# Altium schematic sheet KeyBoard.SchDoc
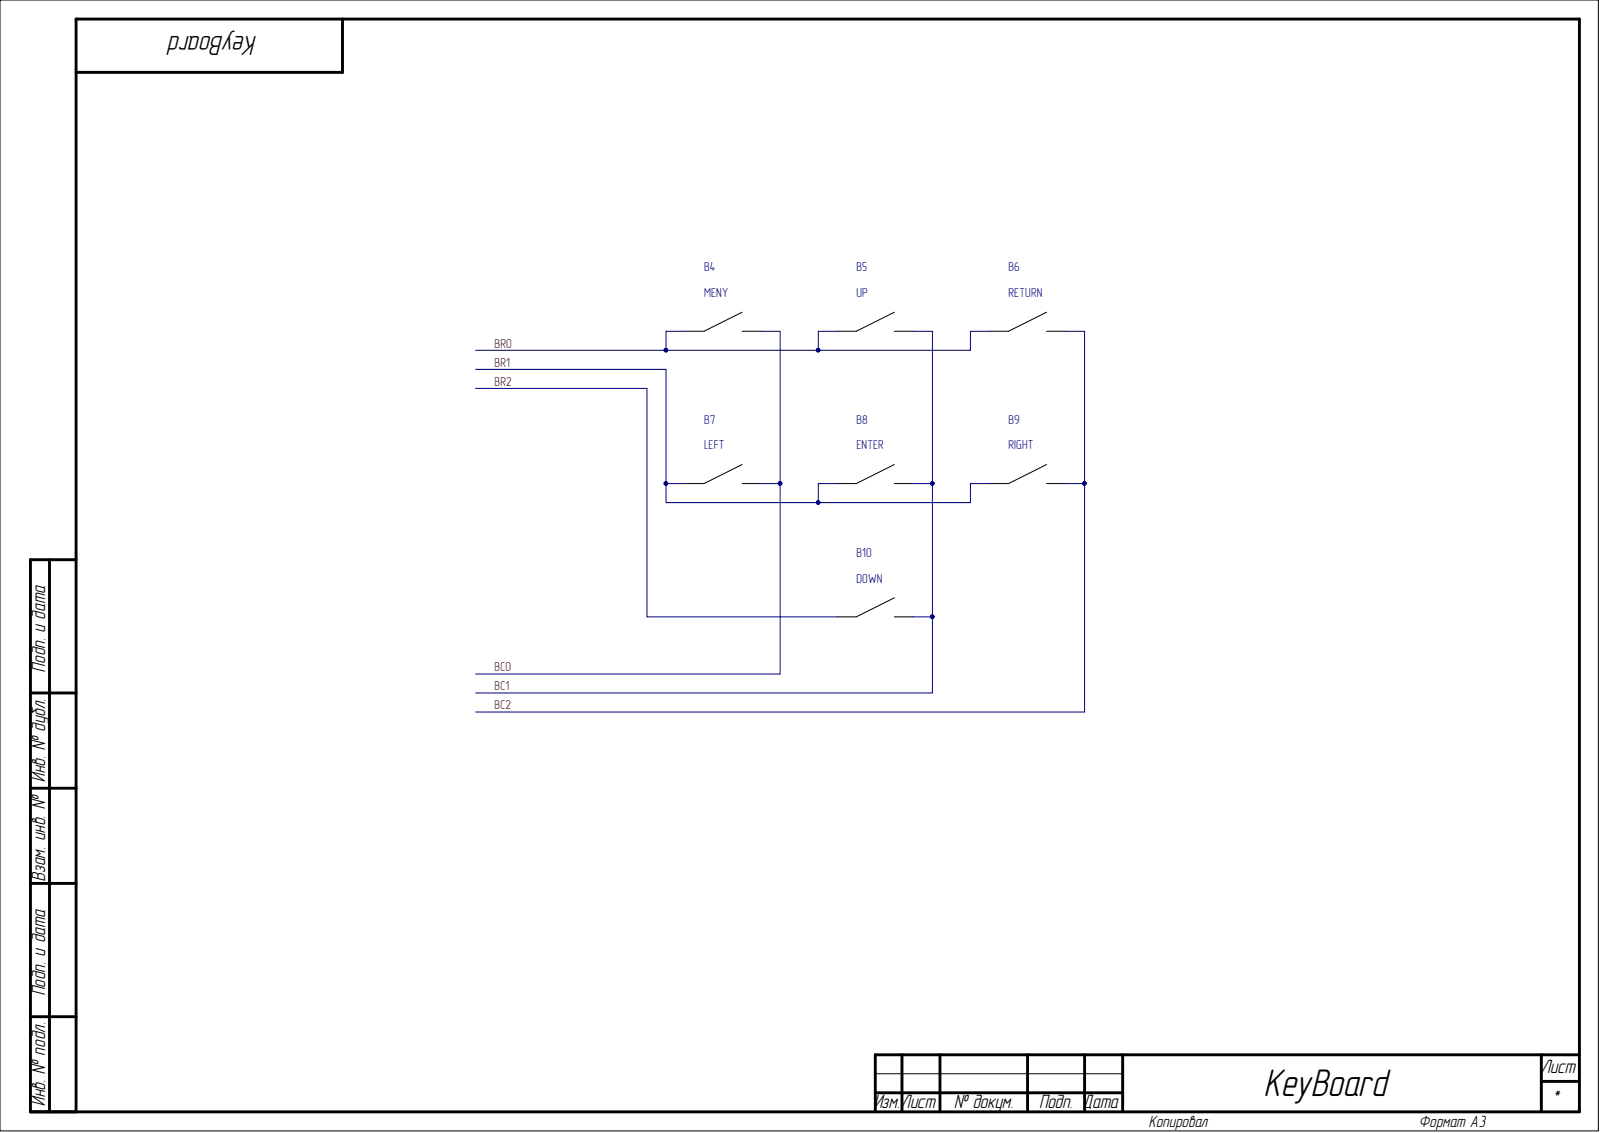
[diagram p0: KeyBoard.SchDoc]

## Parts
B4  BUTTON  [BUTTON_DIP]  (value not drawn)
B5  BUTTON  [BUTTON_DIP]  (value not drawn)
B6  BUTTON  [BUTTON_DIP]  (value not drawn)
B7  BUTTON  [BUTTON_DIP]  (value not drawn)
B8  BUTTON  [BUTTON_DIP]  (value not drawn)
B9  BUTTON  [BUTTON_DIP]  (value not drawn)
B10  BUTTON  [BUTTON_DIP]  (value not drawn)

## Nets
BC0: B4.2 B7.2
BC1: B5.2 B8.2 B10.2
BC2: B6.2 B9.2
BR0: B4.1 B5.1 B6.1
BR1: B7.1 B8.1 B9.1
BR2: B10.1

## Library data of the parts
B4-B10 = BUTTON: Component Kind: Standard; Library Name: my_lib.SchLib
